# Altium schematic sheet A6-Mini.SchDoc
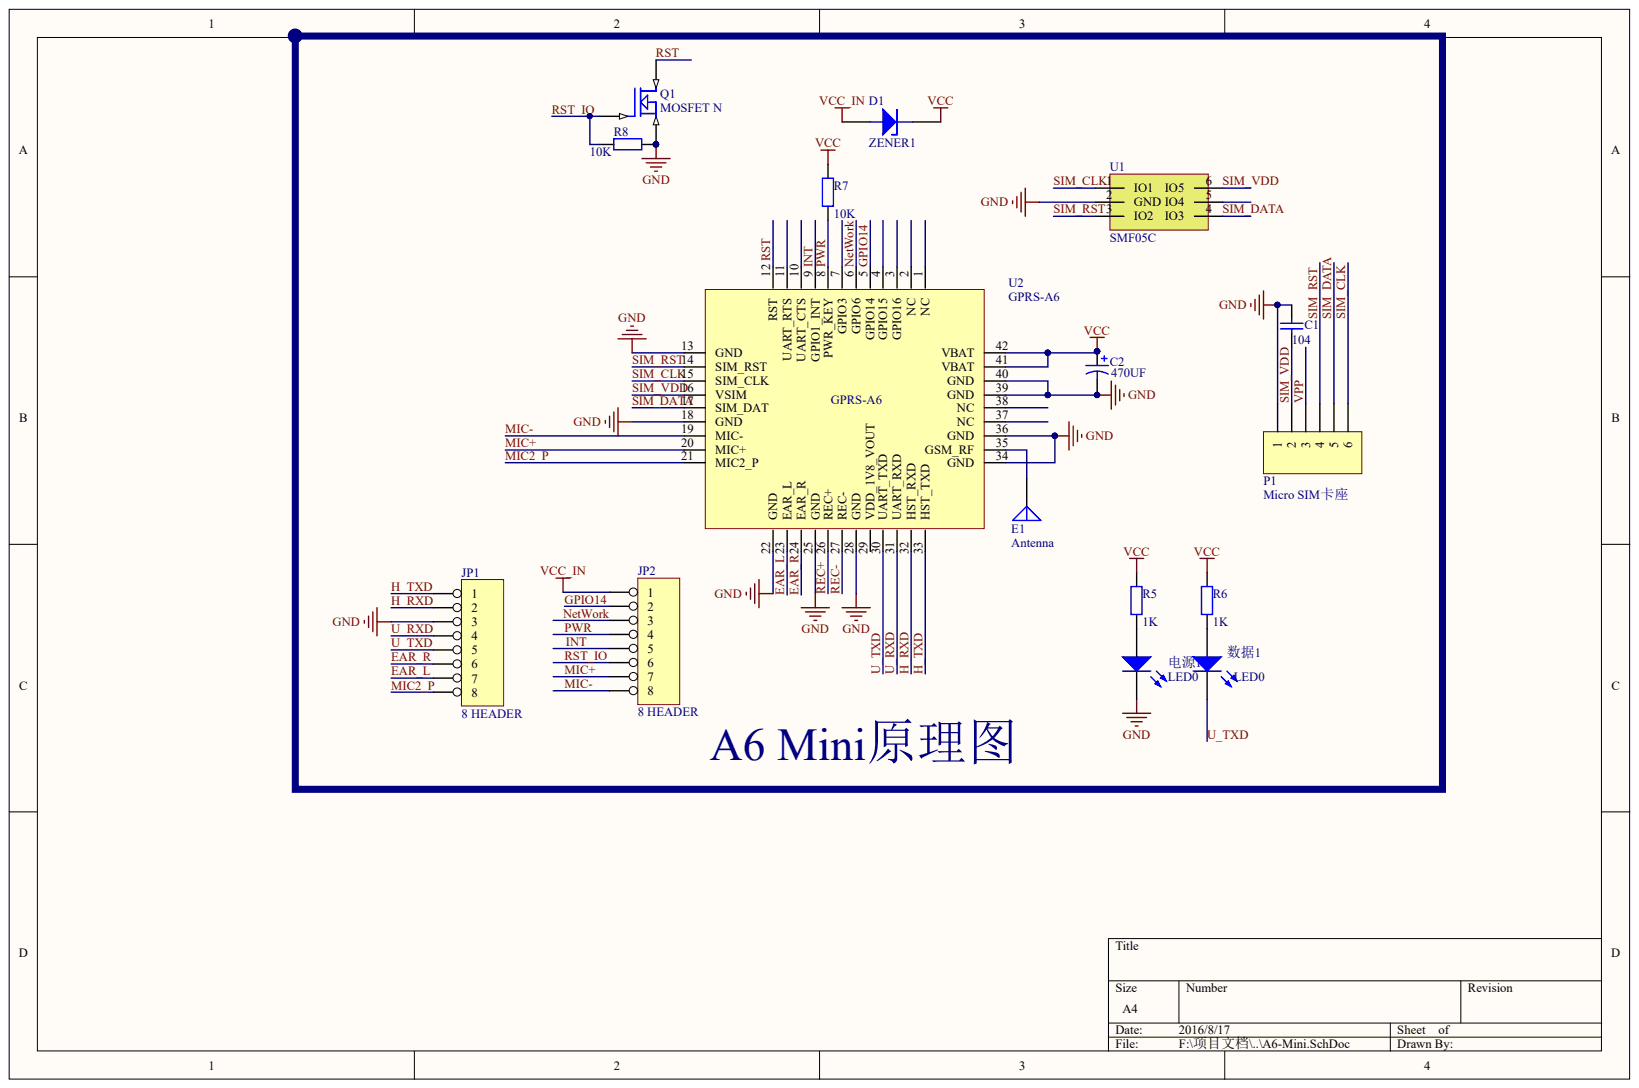
[diagram p0: A6-Mini.SchDoc]

## Parts
C1  value 104  (hidden comment: Cap)
C2  value 470UF
D1  ZENER1
E1  Antenna
JP1  8 HEADER
JP2  8 HEADER
P1  Micro SIM卡座
Q1  MOSFET N
R5  value 1K
R6  value 1K
R7  value 10K
R8  value 10K
U1  SMF05C
U2  GPRS-A6
数据1  LED0
电源1  LED0

## Nets
GND: C1.1 C2.2 JP1.3 P1.1 Q1.3 R8.2 U1.2(GND) U2.13(GND) U2.18(GND) U2.22(GND) U2.25(GND) U2.28(GND) U2.34(GND) U2.36(GND) U2.39(GND) U2.40(GND) 电源1.2
VCC: C2.1 D1.2 R5.2 R6.2 R7.1 U2.41(VBAT) U2.42(VBAT)
VCC_IN: D1.1 JP2.1
EAR_L: JP1.7 U2.23(EAR_L)
EAR_R: JP1.6 U2.24(EAR_R)
GPIO14: JP2.2 U2.5(GPIO14)
H_RXD: JP1.2 U2.32(HST_RXD)
H_TXD: JP1.1 U2.33(HST_TXD)
INT: JP2.5 U2.9(GPIO1_INT)
MIC2_P: JP1.8 U2.21(MIC2_P)
MIC+: JP2.7 U2.20(MIC+)
MIC-: JP2.8 U2.19(MIC-)
NETWORK: JP2.3 U2.6(GPIO6)
PWR: JP2.4 R7.2 U2.8(PWR_KEY)
REC+: U2.26(REC+)
REC-: U2.27(REC-)
RST: Q1.2 U2.12(RST)
RST_IO: JP2.6 Q1.1 R8.1
SIM_CLK: P1.6 U1.1(IO1) U2.15(SIM_CLK)
SIM_DATA: P1.5 U1.4(IO3) U2.17(SIM_DAT)
SIM_RST: P1.4 U1.3(IO2) U2.14(SIM_RST)
SIM_VDD: C1.2 P1.2 U1.6(IO5) U2.16(VSIM)
U_RXD: JP1.4 U2.31(UART_RXD)
U_TXD: JP1.5 U2.30(UART_TXD) 数据1.2
VPP: P1.3
NetE1_1: E1.1 U2.35(GSM_RF)
NetR5_1: R5.1 电源1.1
NetR6_1: R6.1 数据1.1
N00011:

Not connected: U1 5(IO4); U2 1(NC) 2(NC) 3(GPIO16) 4(GPIO15) 7(GPIO3) 10(UART_CTS) 11(UART_RTS) 29(VDD_1V8_VOUT) 37(NC) 38(NC)

## Library data of the parts
C1 C2 D1 E1 JP1 JP2 P1 Q1 R5-R8 U1 U2 数据1 电源1 = (no symbol name)
  C1: Published: 8-Jun-2000; LatestRevisionDate: 17-Jul-2002; LatestRevisionNote: Re-released for DXP Platform.; PackageReference: RAD-0.3; PackageDescription: Radial Cap, Thru-Hole; 2 Leads; 0.3 in Pin Spacing
  C2: Published: 8-Jun-2000; LatestRevisionDate: 17-Jul-2002; LatestRevisionNote: Re-released for DXP Platform.; PackageReference: RB7.6-15; PackageDescription: Polarized Capacitor (Radial); 2 Leads
  E1: Published: 24-Mar-1999; LatestRevisionDate: 17-Jul-2002; LatestRevisionNote: Re-released for DXP Platform.; PackageReference: PIN1
  P1: LatestRevisionDate: 17-Jul-2002; LatestRevisionNote: Re-released for DXP Platform.
  R5-R8: Published: 8-Jun-2000; LatestRevisionDate: 17-Jul-2002; LatestRevisionNote: Re-released for DXP Platform.; PackageReference: AXIAL-0.4; PackageDescription: Axial Device, Thru-Hole; 2 Leads; 0.4 in Pin Spacing
  数据1 电源1: Published: 8-Jun-2000; LatestRevisionDate: 08-Jul-2005; LatestRevisionNote: Mech Layer 1 removed.; PackageReference: LED-0; PackageDescription: LED; 2 Leads
  C1 C2 E1 P1 R5-R8 数据1 电源1: Publisher: Altium Limited
